# Altium schematic sheet int_usb.SchDoc
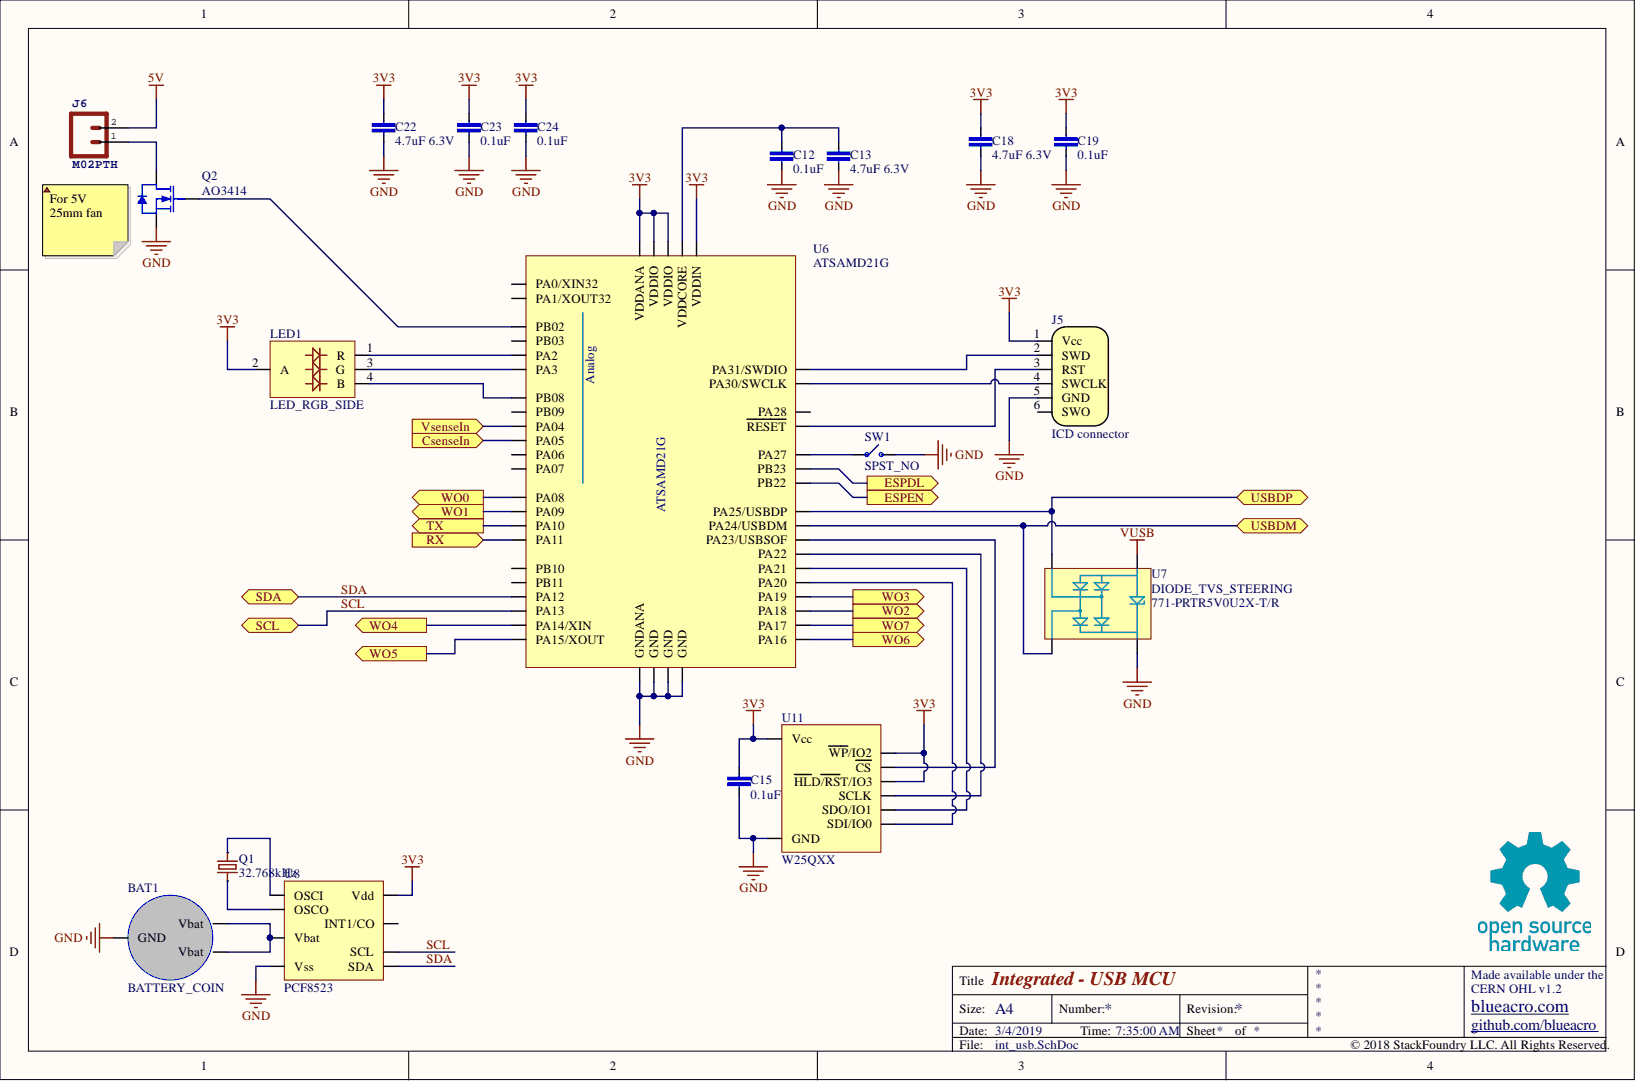
[diagram p0: int_usb.SchDoc]

## Parts
BAT1  BATTERY_COIN  [BK912-2032]
C12  0.1uF  [C0603]
C13  4.7uF 6.3V  [C0603]
C15  0.1uF  [C0603]
C18  4.7uF 6.3V  [C0603]
C19  0.1uF  [C0603]
C22  4.7uF 6.3V  [C0603]
C23  0.1uF  [C0603]
C24  0.1uF  [C0603]
J5  ICD connector  [TC2030-MCP-NL]  (no BOM)
J6  M02PTH  [1X02]
LED1  LED_RGB_SIDE  [KINGBRIGHT_APFA]
Q1  32.768kHz  [CRYSTAL-32KHZ-SMD]
Q2  AO3414  [SOT23-3]
SW1  SPST_NO  [TE_1825027-8]
U6  ATSAMD21G  [TQFP48]
U7  DIODE_TVS_STEERING  Supplier Part Number 1: 771-PRTR5V0U2X-T/R  [SOT143-R]
U8  PCF8523  [SO-8]
U11  W25QXX  [F-SO-08]

## Ports
CsenseIn, ESPDL, ESPEN, RX, SCL, SDA, TX, USBDM, USBDP, VsenseIn, WO0, WO1, WO2, WO3, WO4, WO5, WO6, WO7

## Nets
3V3: C15.1 C18.1 C19.1 C22.1 C23.1 C24.1 J5.1(Vcc) LED1.2(A) U6.6(VDDANA) U6.17(VDDIO) U6.36(VDDIO) U6.44(VDDIN) U8.8(Vdd) U11.3(WP/IO2) U11.7(HLD/RST/IO3) U11.8(Vcc)
5V: J6.2
GND: BAT1.GND C12.2 C13.2 C15.2 C18.2 C19.2 C22.2 C23.2 C24.2 J5.5(GND) Q2.2 SW1.1 U6.5(GNDANA) U6.18(GND) U6.35(GND) U6.42(GND) U7.4 U8.4(Vss) U11.4(GND)
VUSB: U7.1
CsenseIn: U6.10(PA05) ; port CsenseIn
ESPDL: U6.38(PB23) ; port ESPDL
ESPEN: U6.37(PB22) ; port ESPEN
RX: U6.16(PA11) ; port RX
SCL: U6.22(PA13) U8.6(SCL) ; port SCL
SDA: U6.21(PA12) U8.5(SDA) ; port SDA
TX: U6.15(PA10) ; port TX
USBDM: U6.33(PA24/USBDM) U7.3 ; port USBDM
USBDP: U6.34(PA25/USBDP) U7.2 ; port USBDP
VsenseIn: U6.9(PA04) ; port VsenseIn
WO0: U6.13(PA08) ; port WO0
WO1: U6.14(PA09) ; port WO1
WO2: U6.27(PA18) ; port WO2
WO3: U6.28(PA19) ; port WO3
WO4: U6.23(PA14/XIN) ; port WO4
WO5: U6.24(PA15/XOUT) ; port WO5
WO6: U6.25(PA16) ; port WO6
WO7: U6.26(PA17) ; port WO7
NetBAT1_VBAT1: BAT1.VBAT1 BAT1.VBAT2 U8.3(Vbat)
NetC12_1: C12.1 C13.1 U6.43(VDDCORE)
NetJ5_2: J5.2(SWD) U6.46(PA31/SWDIO)
NetJ5_3: J5.3(RST) U6.40(RESET)
NetJ5_4: J5.4(SWCLK) U6.45(PA30/SWCLK)
NetJ6_1: J6.1 Q2.3
NetLED1_1: LED1.1(R) U6.3(PA2)
NetLED1_3: LED1.3(G) U6.4(PA3)
NetLED1_4: LED1.4(B) U6.7(PB08)
NetQ1_X1: Q1.X1 U8.2(OSCO)
NetQ1_X2: Q1.X2 U8.1(OSCI)
NetQ2_1: Q2.1 U6.47(PB02)
NetSW1_2: SW1.2 U6.39(PA27)
NetU6_29: U6.29(PA20) U11.5(SDI/IO0)
NetU6_30: U6.30(PA21) U11.2(SDO/IO1)
NetU6_31: U6.31(PA22) U11.6(SCLK)
NetU6_32: U6.32(PA23/USBSOF) U11.1(CS)

Not connected: J5 6(SWO); U6 1(PA0/XIN32) 2(PA1/XOUT32) 8(PB09) 11(PA06) 12(PA07) 19(PB10) 20(PB11) 41(PA28) 48(PB03); U8 7(INT1/CO)

## Library data of the parts
C12 C13 C15 C18 C19 C22-C24 = C-EU: Component Kind: Standard; Library Name: rcl.IntLib
J5 = TC2030-SWD-NL: Conector par ICD; Library Name: TC2030.IntLib
Q1 = CRYSTAL32-SMD: Component Kind: Standard; Library Name: general.IntLib
Q2 = NFET: Component Kind: Standard; Library Name: general.IntLib
BAT1 = BATTERY_COIN: Component Kind: Standard; Library Name: connectors.IntLib
J6 = M02PTH: Component Kind: Standard; Library Name: connectors.IntLib
LED1 = LED_RGB_SIDE: Component Kind: Standard; Library Name: led.IntLib
SW1 = SPST_NO: Component Kind: Standard; Library Name: switches.IntLib
U6 = ATSAMD21G: Component Kind: Standard; Library Name: atmel.IntLib
U7 = DIODE_TVS_STEERING: Component Kind: Standard; Library Name: general.IntLib; Supplier 1: Mouser
U8 = PCF8523: Component Kind: Standard; Library Name: general.IntLib
U11 = W25QXX: Component Kind: Standard; Library Name: winbond-flash.IntLib
